# Altium schematic sheet 04_POWER_LDO.SchDoc(04_POWER_LDO)
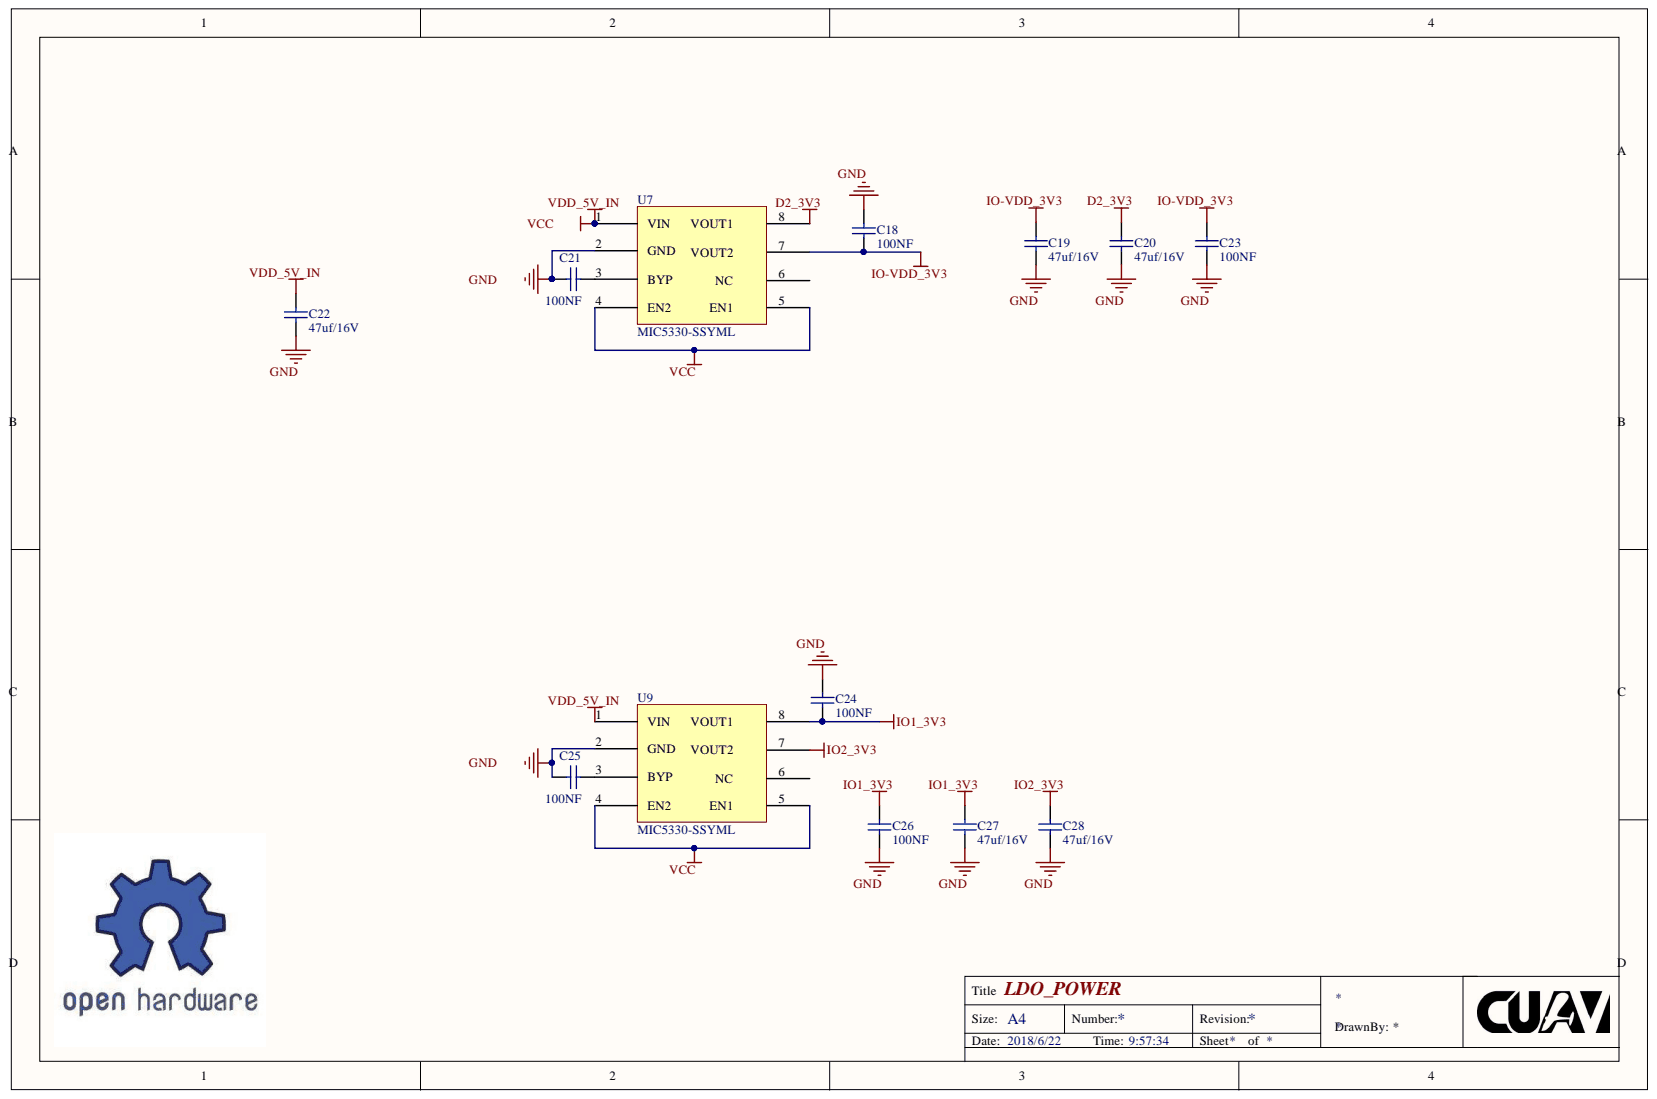
[diagram p0: 04_POWER_LDO.SchDoc]

## Parts
C18  100NF  [0402CAP]
C19  47uf/16V  [0805CAP]
C20  47uf/16V  [0805CAP]
C21  100NF  [0402CAP]
C22  47uf/16V  [0805CAP]
C23  100NF  [0402CAP]
C24  100NF  [0402CAP]
C25  100NF  [0402CAP]
C26  100NF  [0402CAP]
C27  47uf/16V  [0805CAP]
C28  47uf/16V  [0805CAP]
U7  MIC5330-SSYML  [MIC5530]
U9  MIC5330-SSYML  [MIC5530]

## Nets
D2_3V3: C20.1 U7.8
GND: C18.2 C19.2 C20.2 C21.2 C22.2 C23.1 C24.2 C25.2 C26.1 C27.2 C28.2 U7.2(GND) U9.2(GND)
IO1_3V3: C24.1 C26.2 C27.1 U9.8
IO2_3V3: C28.1 U9.7
IO-VDD_3V3: C18.1 C19.1 C23.2 U7.7
VCC: C22.1 U7.1(VIN) U7.4(EN2) U7.5 U9.1(VIN) U9.4(EN2) U9.5
NetC21_1: C21.1 U7.3(BYP)
NetC25_1: C25.1 U9.3(BYP)

Not connected: U7 6; U9 6

## Library data of the parts
C18 C21 C24-C26 = Cap: 0402 100NF 50V 10%; Component Kind: Standard; Library Name: Miscellaneous Devices.IntLib; Signal Integrity: Cap; Simulation: CAP
C19 C20 C22 C27 C28 = Cap: 0805 47UF 16V; Component Kind: Standard; Library Name: Miscellaneous Devices.IntLib; Signal Integrity: Cap; Simulation: CAP
C23 = Cap: Component Kind: Standard; Library Name: Miscellaneous Devices.IntLib; Signal Integrity: Cap; Simulation: CAP
U7 U9 = MIC5530: Component Kind: Standard; Library Name: hack.SchLib
